# Altium schematic sheet Sheet2.SchDoc(Sheet2)
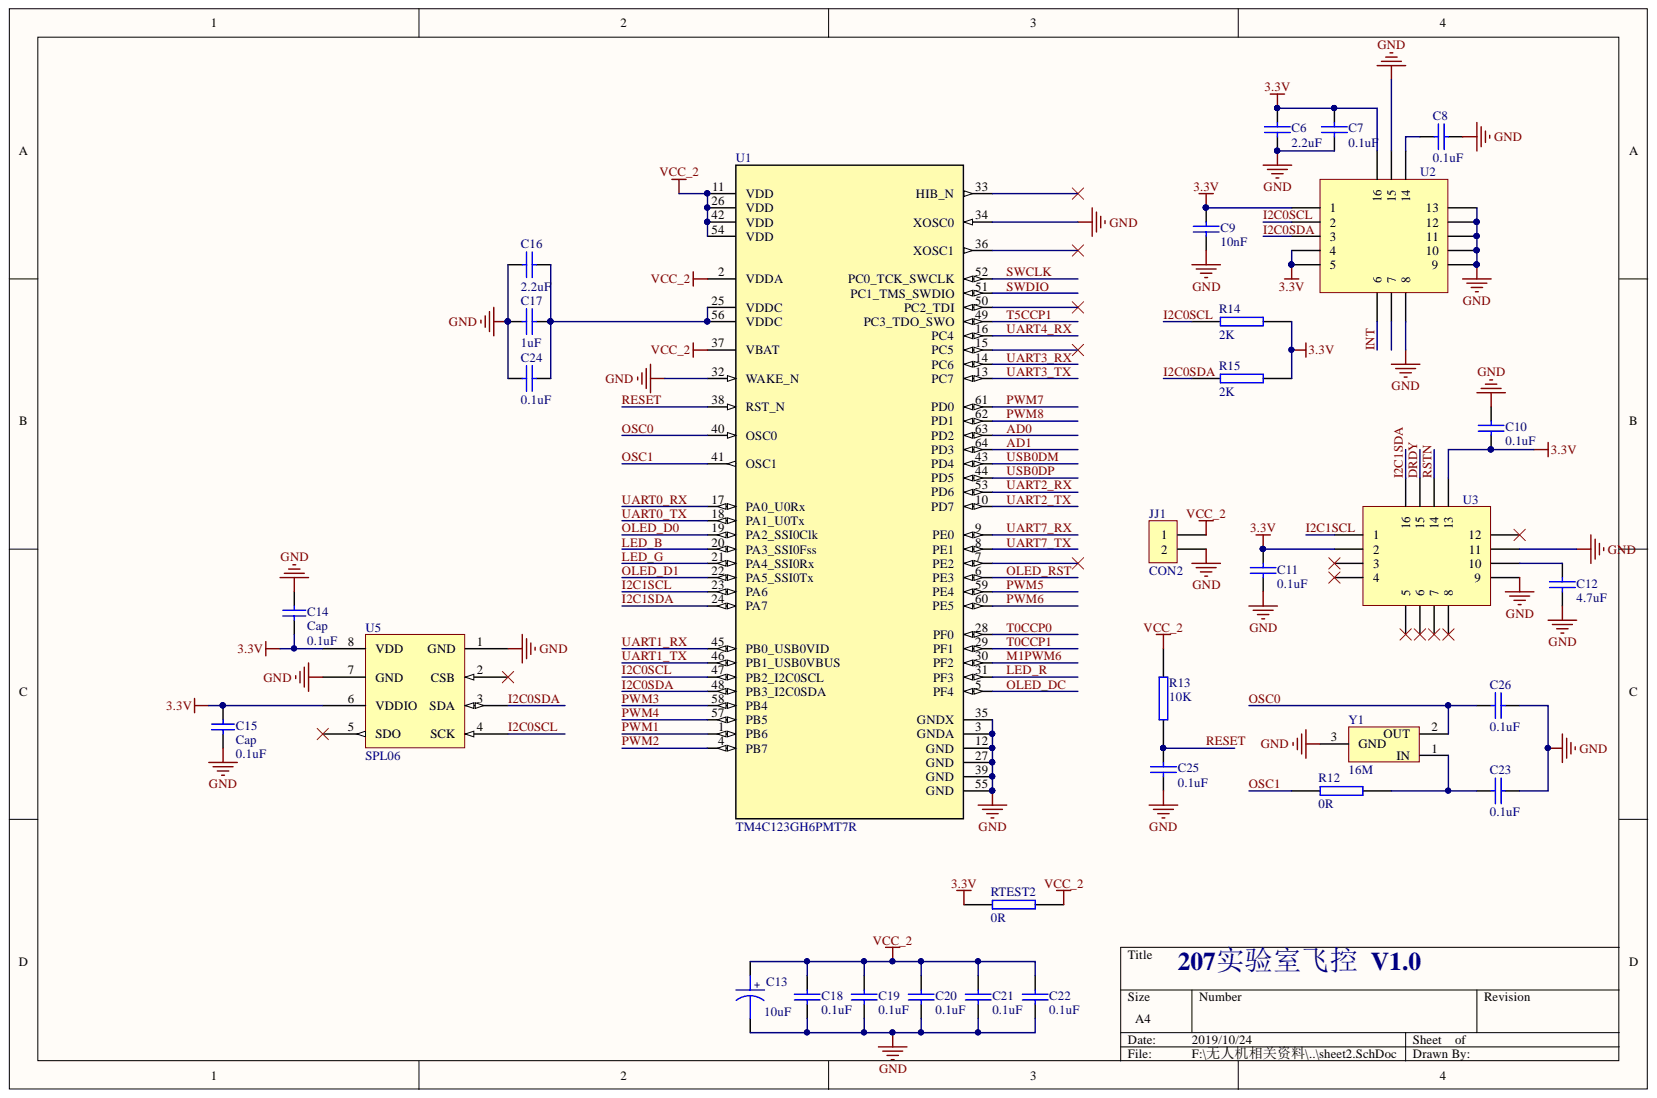
[diagram p0: Sheet2.SchDoc]

## Parts
C6  2.2uF  [C0603]
C7  0.1uF  [C0603]
C8  0.1uF  [C0603]
C9  10nF  [C0603]
C10  0.1uF  [C0603]
C11  0.1uF  [C0603]
C12  4.7uF  [C0603]
C13  10uF  [1206]
C14  Cap  value 0.1uF  [0603]
C15  Cap  value 0.1uF  [0603]
C16  2.2uF  [C0603]
C17  1uF  [C0603]
C18  0.1uF  [C0603]
C19  0.1uF  [C0603]
C20  0.1uF  [C0603]
C21  0.1uF  [C0603]
C22  0.1uF  [C0603]
C23  0.1uF  [C0603]
C24  0.1uF  [C0603]
C25  0.1uF  [C0603]
C26  0.1uF  [C0603]
JJ1  CON2  [HDR1X2]
R12  0R  [R0603]
R13  10K  [R0603]
R14  2K  [R0603]
R15  2K  [R0603]
RTEST2  0R  [R0603]
U1  TM4C123GH6PMT7R  [PM0064A_N]
U2  VDDIO  [LSM303D]  (value not drawn)
U3  SCL_33  [L3GD20]  (value not drawn)
U5  SPL06  [SPL06]
Y1  16M  [XT25]

## Nets
3.3V: C6.2 C7.2 C9.2 C10.2 C11.2 C14.2 C15.2 R14.1 R15.1 RTEST2.2 U2.1 U2.4 U2.5 U2.16 U3.2 U3.13 U5.6(VDDIO) U5.8(VDD)
GND: C6.1 C7.1 C8.2 C9.1 C10.1 C11.1 C12.1 C13.2 C14.1 C15.1 C16.1 C17.1 C18.2 C19.2 C20.2 C21.2 C22.2 C23.2 C24.1 C25.1 C26.1 JJ1.2 U1.3(GNDA) U1.12(GND) U1.27(GND) U1.32(WAKE_N) U1.34(XOSC0) U1.35(GNDX) U1.39(GND) U1.55(GND) U2.8 U2.9 U2.10 U2.11 U2.12 U2.13 U2.15 U3.9 U3.11 U5.1(GND) U5.7(GND) Y1.3
VCC_2: C13.1 C18.1 C19.1 C20.1 C21.1 C22.1 JJ1.1 R13.1 RTEST2.1 U1.2(VDDA) U1.11(VDD) U1.26(VDD) U1.37(VBAT) U1.42(VDD) U1.54(VDD)
AD0: U1.63(PD2)
AD1: U1.64(PD3)
DRDY: U3.15
I2C0SCL: R14.2 U1.47(PB2_I2C0SCL) U2.2 U5.4(SCK)
I2C0SDA: R15.2 U1.48(PB3_I2C0SDA) U2.3 U5.3(SDA)
I2C1SCL: U1.23(PA6) U3.1
I2C1SDA: U1.24(PA7) U3.16
INT: U2.6
LED_B: U1.20(PA3_SSI0Fss)
LED_G: U1.21(PA4_SSI0Rx)
LED_R: U1.31(PF3)
M1PWM6: U1.30(PF2)
OLED_D0: U1.19(PA2_SSI0Clk)
OLED_D1: U1.22(PA5_SSI0Tx)
OLED_DC: U1.5(PF4)
OLED_RST: U1.6(PE3)
OSC0: C26.2 U1.40(OSC0) Y1.2
OSC1: R12.2 U1.41(OSC1)
PWM1: U1.1(PB6)
PWM2: U1.4(PB7)
PWM3: U1.58(PB4)
PWM4: U1.57(PB5)
PWM5: U1.59(PE4)
PWM6: U1.60(PE5)
PWM7: U1.61(PD0)
PWM8: U1.62(PD1)
RESET: C25.2 R13.2 U1.38(RST_N)
RSTN: U3.14
SWCLK: U1.52(PC0_TCK_SWCLK)
SWDIO: U1.51(PC1_TMS_SWDIO)
T0CCP0: U1.28(PF0)
T0CCP1: U1.29(PF1)
T5CCP1: U1.49(PC3_TDO_SWO)
UART0_RX: U1.17(PA0_U0Rx)
UART0_TX: U1.18(PA1_U0Tx)
UART1_RX: U1.45(PB0_USB0VID)
UART1_TX: U1.46(PB1_USB0VBUS)
UART2_RX: U1.53(PD6)
UART2_TX: U1.10(PD7)
UART3_RX: U1.14(PC6)
UART3_TX: U1.13(PC7)
UART4_RX: U1.16(PC4)
UART7_RX: U1.9(PE0)
UART7_TX: U1.8(PE1)
USB0DM: U1.43(PD4)
USB0DP: U1.44(PD5)
NetC8_1: C8.1 U2.14
NetC12_2: C12.2 U3.10
NetC16_2: C16.2 C17.2 C24.2 U1.25(VDDC) U1.56(VDDC)
NetC23_1: C23.1 R12.1 Y1.1

Not connected: U1 7(PE2) 15(PC5) 33(HIB_N) 36(XOSC1) 50(PC2_TDI); U2 7; U3 3 4 5 6 7 8 12; U5 2(CSB) 5(SDO)

## Library data of the parts
C6-C12 C16-C26 = CAP: Capacitor; Component Kind: Standard; Library Name: Backup of Miscellaneous Devices.lib
C13 = CAPACITOR POL: Capacitor; Component Kind: Standard; Library Name: Backup of Miscellaneous Devices.lib
C14 C15 = Cap: Capacitor; Component Kind: Standard; LatestRevisionDate: 17-Jul-2002; LatestRevisionNote: Re-released for DXP Platform.; Library Name: Miscellaneous Devices.IntLib; PackageDescription: Radial Cap, Thru-Hole; 2 Leads; 0.3 in Pin Spacing; PackageReference: RAD-0.3; Published: 8-Jun-2000; Signal Integrity: Cap; Simulation: CAP; Publisher: Altium Limited
JJ1 = CON2: Connector; Component Kind: Standard; Library Name: Backup of Miscellaneous Devices.lib
R12-R15 RTEST2 = RES2: Component Kind: Standard; Library Name: Backup of Miscellaneous Devices.lib
U1 = TM4C123GH6PMT7R: Imported; Manufacturer: Texas Instruments; PartNumber: TM4C123GH6PMTR; CompType: IC; PIN_COUNT: 64; Alternate_PartNumber: TM4C123GH6PMT; Component Kind: Standard; Library Name: TM4C123GH6PM.SchLib; MountType: SMT; PackageReference: PM0064A; RefDes: RefDes; RoHS: Y; TYPE: TYPE; Value: Value
Y1 = 12M: Component Kind: Standard; Library Name: Backup of Miscellaneous Devices.lib
U2 = VDDIO: Component Kind: Standard; Library Name: Miscellaneous Devices.lib
U3 = SCL_33: Component Kind: Standard; Library Name: Miscellaneous Devices.lib
U5 = SPL06: Component Kind: Standard; Library Name: SchlibSensors.SchLib
